# Altium schematic sheet Buttons.SchDoc(Buttons)
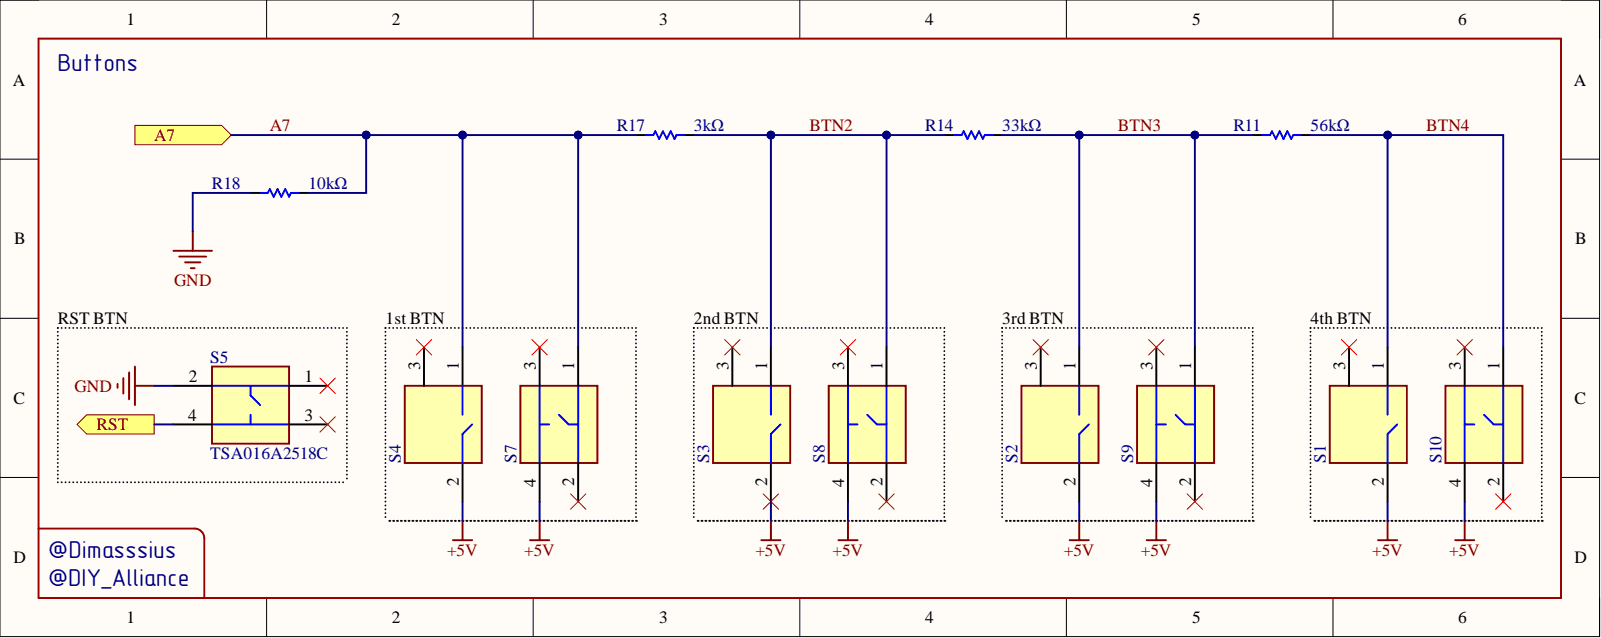
[diagram p0: Buttons.SchDoc]

## Parts
R11  56kΩ  [RES_SMD_R0603_(M1608)_R0402A_(M1005A)]
R14  33kΩ  [RES_SMD_R0603_(M1608)_R0402A_(M1005A)]
R17  3kΩ  [RES_SMD_R0603_(M1608)_R0402A_(M1005A)]
R18  10kΩ  [RES_SMD_R0603_(M1608)_R0402A_(M1005A)]
S1  TS-1009B  [TS-1009B]  (value not drawn)
S2  TS-1009B  [TS-1009B]  (value not drawn)
S3  TS-1009B  [TS-1009B]  (value not drawn)
S4  TS-1009B  [TS-1009B]  (value not drawn)
S5  TSA016A2518C  [TS20]
S7  TS-1187A-B-A-B  [TS-1187A-B-A-B]  (value not drawn)
S8  TS-1187A-B-A-B  [TS-1187A-B-A-B]  (value not drawn)
S9  TS-1187A-B-A-B  [TS-1187A-B-A-B]  (value not drawn)
S10  TS-1187A-B-A-B  [TS-1187A-B-A-B]  (value not drawn)

## Ports
A7, RST

## Nets
+5V: S1.2 S2.2 S3.2 S4.2 S7.4 S8.4 S9.4 S10.4
GND: R18.1 S5.2
A7: R17.1 R18.2 S4.1 S7.1 ; port A7
BTN2: R14.1 R17.2 S3.1 S8.1
BTN3: R11.1 R14.2 S2.1 S9.1
BTN4: R11.2 S1.1 S10.1
RST: S5.4 ; port RST

Not connected: S1 3; S2 3; S3 3; S4 3; S5 1 3; S7 2 3; S8 2 3; S9 2 3; S10 2 3

## Library data of the parts
R11 = RES_SMD_56KΩ: Resistor, Thick Film Resistor, SMD, 56kΩ, ±5%, Chip Resistor - Surface Mount, ROHS; Component Kind: Standard; Library Name: Resistors.SchLib; Value: 56kΩ
R14 = RES_SMD_33KΩ: Resistor, Thick Film Resistor, SMD, 33kΩ, ±5%, Chip Resistor - Surface Mount, ROHS; Component Kind: Standard; Library Name: Resistors.SchLib; Value: 33kΩ
R17 = RES_SMD_3KΩ: Resistor, Thick Film Resistor, SMD, 3kΩ, ±5%, Chip Resistor - Surface Mount, ROHS; Component Kind: Standard; Library Name: Resistors.SchLib; Value: 3kΩ
R18 = RES_SMD_10KΩ: Resistor, Thick Film Resistor, SMD, 10kΩ, ±5%, Chip Resistor - Surface Mount, ROHS; Component Kind: Standard; Library Name: Resistors.SchLib; Value: 10kΩ
S1-S4 = TS-1009B: Switch Tactile, Through Hole, 4.5x4.5mm, Round Button, 50mA, Bend-in 4.5mm, SPST, 12V, Plugin, Tactile Switches, ROHS; Component Kind: Standard; Datasheet Link: https://www.mouser.com/datasheet/2/140/TL59-TL58-346345-1369262.pdf; Height: 5mm; Library Name: SamacSys.SchLib; Value: TS-1009B
S5 = TSA016A2518C: Switch Tactile, SMD, 3.35x2.5mm, Oval button, 50mA, Standing paste, 4.2mm ,180gf, 12V, SMD Tactile Switches, ROHS; Component Kind: Standard; Datasheet Link: http://www.alps.com/prod/info/E/HTML/Tact/SurfaceMount/SKRP/SKRPACE010.html; Library Name: SamacSys.SchLib; Value: TSA016A2518C
S7-S10 = TS-1187A-B-A-B: Switch Tactile, SMD, 5.1x5.1mm, Without bracket, 50mA, 100000 Times, 160gf, 12V, Round, SMD Tactile Switches, ROHS; Component Kind: Standard; Library Name: SamacSys.SchLib; Package: None; Value: TS-1187A-B-A-B
